# Altium schematic sheet ESP.SchDoc
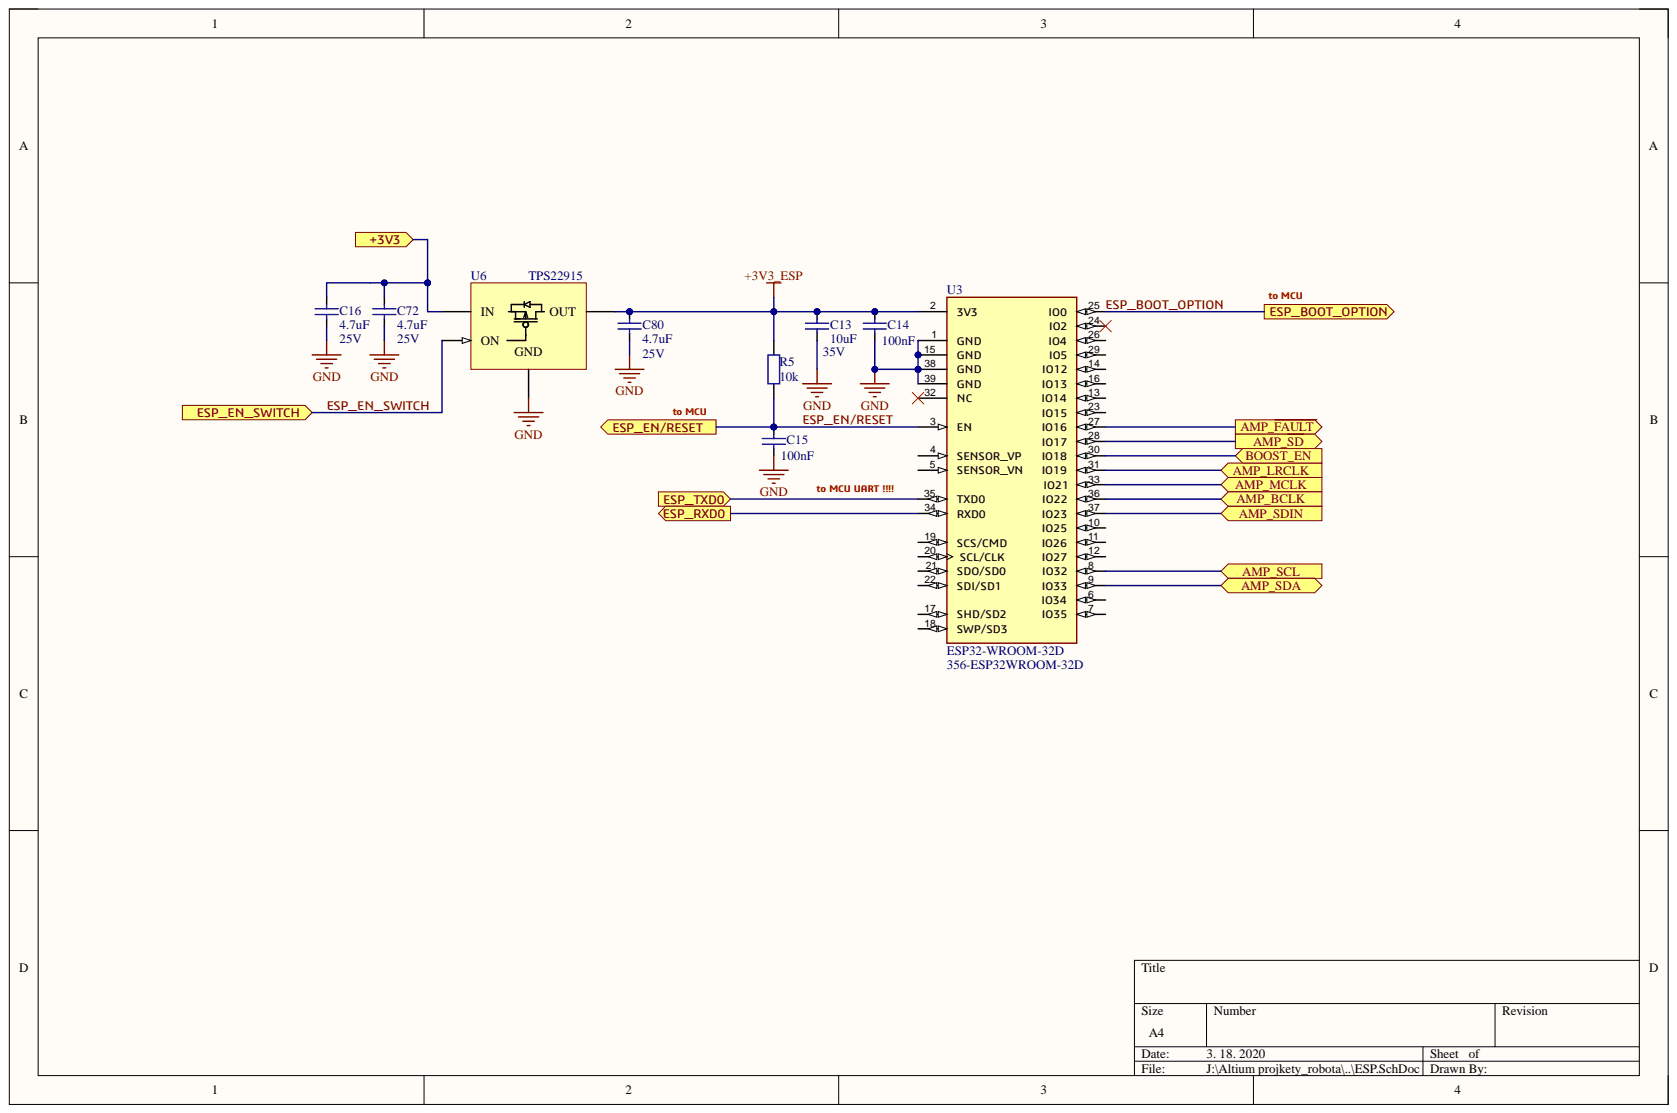
[diagram p0: ESP.SchDoc]

## Parts
C13  10uF  Voltage Rating: 35V  [CAPACITOR_0805_(2012)]
C14  100nF  [CAPACITOR_0402_(1005)]
C15  100nF  [CAPACITOR_0402_(1005)]
C16  4.7uF  Voltage: 25V  [CAPACITOR_0603_(1608)]
C72  4.7uF  Voltage: 25V  [CAPACITOR_0603_(1608)]
C80  4.7uF  Voltage: 25V  [CAPACITOR_0603_(1608)]
R5  10k  [RESISTOR_0603_(1608)]
U3  ESP32-WROOM-32D  Supplier Part Number 1: 356-ESP32WROOM-32D  [ESP32-WROOM-32D]
U6  TPS22915  [DSBGA4_0.4mmpitch]

## Ports
+3V3, AMP_BCLK, AMP_LRCLK, AMP_MCLK, AMP_SCL, AMP_SDA, AMP_SDIN, AMP_~{FAULT}, AMP_~{SD}, BOOST_EN, ESP_BOOT_OPTION, ESP_EN/RESET, ESP_EN_SWITCH, ESP_RXD0, ESP_TXD0

## Nets
+3V3_ESP: C13.1 C14.1 C80.1 R5.1 U3.2(3V3) U6.A1(OUT)
GND: C13.2 C14.2 C15.2 C16.2 C72.2 C80.2 U3.1(GND) U3.15(GND) U3.38(GND) U3.39(GND) U6.B1
+3V3: C16.1 C72.1 U6.A2(IN) ; port +3V3
AMP_BCLK: U3.36(IO22) ; port AMP_BCLK
AMP_~{FAULT}: U3.27(IO16) ; port AMP_~{FAULT}
AMP_LRCLK: U3.31(IO19) ; port AMP_LRCLK
AMP_MCLK: U3.33(IO21) ; port AMP_MCLK
AMP_~{SD}: U3.28(IO17) ; port AMP_~{SD}
AMP_SCL: U3.8(IO32) ; port AMP_SCL
AMP_SDA: U3.9(IO33) ; port AMP_SDA
AMP_SDIN: U3.37(IO23) ; port AMP_SDIN
BOOST_EN: U3.30(IO18) ; port BOOST_EN
ESP_BOOT_OPTION: U3.25(IO0) ; port ESP_BOOT_OPTION
ESP_EN/RESET: C15.1 R5.2 U3.3(EN) ; port ESP_EN/RESET
ESP_EN_SWITCH: U6.B2(ON) ; port ESP_EN_SWITCH
ESP_RXD0: U3.34(RXD0) ; port ESP_RXD0
ESP_TXD0: U3.35(TXD0) ; port ESP_TXD0

Not connected: U3 4(SENSOR_VP) 5(SENSOR_VN) 6(IO34) 7(IO35) 10(IO25) 11(IO26) 12(IO27) 13(IO14) 14(IO12) 16(IO13) 17(SHD/SD2) 18(SWP/SD3) 19(SCS/CMD) 20(SCL/CLK) 21(SDO/SD0) 22(SDI/SD1) 23(IO15) 24(IO2) 26(IO4) 29(IO5) 32(NC)

## Library data of the parts
C13 = CAPACITOR: Manufacturer 1: Murata; Manufacturer Part Number 1: GRM21BR6YA106KE43L; Dielectric: X5R; Tolerance: 10%; Capacitance: 10uF; Case Code (Imperial): 0805; Case Code (Metric): 2012; Case/Package: 0805; Component Kind: Standard; ComponentLink1Description: http://www.farnell.com/datasheets/2079187.pdf?src-supplier=Farnell; ComponentLink1URL: http://www.farnell.com/datasheets/2079187.pdf?src-supplier=Farnell; ComponentLink2Description: http://www.mouser.com/ds/2/281/c02e-2905.pdf?src-supplier=Mouser; ComponentLink2URL: http://www.mouser.com/ds/2/281/c02e-2905.pdf?src-supplier=Mouser; ComponentLink3Description: http://search.murata.co.jp/Ceramy/image/img/A01X/G101/ENG/GRM21BR6YA106KE43-01.pdf?src-supplier=Digi-Key; ComponentLink3URL: http://search.murata.co.jp/Ceramy/image/img/A01X/G101/ENG/GRM21BR6YA106KE43-01.pdf?src-supplier=Digi-Key; ComponentLink4Description: http://search.murata.co.jp/Ceramy/image/img/A01X/partnumbering_e_01.pdf?src-supplier=Digi-Key; ComponentLink4URL: http://search.murata.co.jp/Ceramy/image/img/A01X/partnumbering_e_01.pdf?src-supplier=Digi-Key; ComponentLink5Description: http://www.farnell.com/datasheets/2079187.pdf?src-supplier=Newark; ComponentLink5URL: http://www.farnell.com/datasheets/2079187.pdf?src-supplier=Newark; ComponentLink6Description: http://www.farnell.com/datasheets/2079187.pdf?src-supplier=Element14; ComponentLink6URL: http://www.farnell.com/datasheets/2079187.pdf?src-supplier=Element14; Description: CAPACITOR; Height: 1.25mm; Lead Free: Lead Free; Length: 0.079inch; Max Operating Temperature: 85°C; Min Operating Temperature: -55°C; Mount: Surface Mount; Package Quantity: 3000; Packaging: Tape and Reel; Pricing 1: 5=0,38700, 50=0,21000, 250=0,18000, 500=0,11500, 1500=0,10500, 3000=0,09410, 15000=0,09070 (EUR); REACH SVHC: No SVHC; RoHS: Yes; Stock 1: 109244; Supplier 1: Farnell; Supplier Part Number 1: 2611943; Termination: SMD/SMT; Thickness: 0.057inch; Voltage Rating (DC): 35V; Weight: 0.000335oz; Width: 0.049inch; Library Name: RLCFB.SchLib
C14 C15 = CAPACITOR: ESR: 0.28R; Voltage Rating: 10V; Capacitance: 100nF; Component Kind: Standard; Description: CAPACITOR; Library Name: RLCFB.SchLib
C16 C72 C80 = CAPACITOR: Manufacturer 1: Murata; Manufacturer Part Number 1: GRM188R61E475KE11D; Dielectric: X5R; ESR: 0.28R; Material: Ceramic; Tolerance: 10%; Capacitance: 4.7uF; Case Code (Imperial): 0603; Case Code (Metric): 1608; Case/Package: 0603; Component Kind: Standard; ComponentLink1Description: https://datasheet.ciiva.com/46444/1769893-46444982.pdf?src-supplier=Element14; ComponentLink1URL: https://datasheet.ciiva.com/46444/1769893-46444982.pdf?src-supplier=Element14; ComponentLink2Description: https://datasheet.ciiva.com/46444/1769893-46444983.pdf?src-supplier=Element14; ComponentLink2URL: https://datasheet.ciiva.com/46444/1769893-46444983.pdf?src-supplier=Element14; ComponentLink3Description: https://datasheet.ciiva.com/14891/partnumbering-e-01-14891102.pdf?src-supplier=Digi-Key; ComponentLink3URL: https://datasheet.ciiva.com/14891/partnumbering-e-01-14891102.pdf?src-supplier=Digi-Key; ComponentLink4Description: https://datasheet.ciiva.com/14891/partnumbering-e-01-14891103.pdf?src-supplier=Digi-Key; ComponentLink4URL: https://datasheet.ciiva.com/14891/partnumbering-e-01-14891103.pdf?src-supplier=Digi-Key; Depth: 0.8mm; Description: CAPACITOR; Height: 0.8mm; Lead Free: Lead Free; Length: 1.6mm; Max Operating Temperature: 85°C; Min Operating Temperature: -55°C; Mount: Surface Mount; Package Quantity: 4000; Packaging: Cut Tape; Pricing 1: 10=0,35700, 100=100,00000, 500=0,15900, 1000=0,12800, 2000=0,10200 (CHF); REACH SVHC: No SVHC; RoHS: Yes; Stock 1: 95119; Supplier 1: Farnell; Supplier Part Number 1: 2426959; Thickness: 0.031inch; Voltage Rating: 25V; Voltage Rating (DC): 25V; Width: 0.031inch; Library Name: RLCFB.SchLib
R5 = RESISTOR: Power Rating: 500mW; Component Kind: Standard; Description: RESISTOR; Resistance: 10k; Library Name: RLCFB.SchLib
U3 = ESP32-WROOM-32D: IC: SoC; GPIO, I2C, I2S, IR, SD, SDIO, SPI, UART; 2.7Ă·3.6VDC; 20dBm; Manufacturer 1: Espressif Systems; Component Kind: Standard; ComponentLink1Description: SOS; ComponentLink1URL: https://www.sos.sk/products/espressif/esp32-wroom-32d-291230; ComponentLink2Description: https://www.espressif.com/sites/default/files/documentation/esp32-wroom-32d_esp32-wroom-32u_datasheet_en.pdf?src-supplier=Digi-Key; ComponentLink2URL: https://www.espressif.com/sites/default/files/documentation/esp32-wroom-32d_esp32-wroom-32u_datasheet_en.pdf?src-supplier=Digi-Key; Library Name: BB1_SCH_Library.SchLib; Manufacturer Part Number 1: ESP32-WROOM-32D; Pricing 1: 1=3,80000, 5=3,80000 (USD); RoHS: No; Stock 1: 1909; Supplier 1: Mouser
U6 = TPS22915: TPS22915  Power Switch ICs - Power Distribution 5.5V, 2A, 38mOhm Load Switch   tR=64 µs  QUICK OUTPUT DISCHARGE=Yes; Manufacturer 1: Texas Instruments; Manufacturer Part Number 1: TPS22915BYFPR; Interface: On/Off; Number of Channels: 1; Output Configuration: High Side; Quiescent Current: 7.7uA; Component Kind: Standard; ComponentLink1Description: http://www.ti.com/lit/ds/symlink/tps22915.pdf?src-supplier=Digi-Key; ComponentLink1URL: http://www.ti.com/lit/ds/symlink/tps22915.pdf?src-supplier=Digi-Key; ComponentLink2Description: http://www.ti.com/general/docs/lit/getliterature.tsp?genericPartNumber=TPS22915&&fileType=pdf&src-supplier=Avnet; ComponentLink2URL: http://www.ti.com/general/docs/lit/getliterature.tsp?genericPartNumber=TPS22915&&fileType=pdf&src-supplier=Avnet; ComponentLink3Description: http://www.ti.com/lit/gpn/tps22915?src-supplier=Mouser; ComponentLink3URL: http://www.ti.com/lit/gpn/tps22915?src-supplier=Mouser; Lead Free: Lead Free; Library Name: BB1_SCH_Library.SchLib; Max Operating Temperature: 85°C; Max Output Current: 2A; Min Operating Temperature: -40°C; Mount: Surface Mount; Number of Outputs: 1; Number of Pins: 4; Packaging: Tape and Reel; Pricing 1: 1=0,42000, 10=0,35000, 100=0,21300, 1000=0,16400, 3000=0,14000, 9000=0,13000 (USD); RoHS: Yes; Stock 1: 3444; Supplier 1: Mouser; Supplier Part Number 1: 595-TPS22915BYFPR
